# Altium schematic sheet Hamamatsu MEG MPPC - Series Hybrid - Rev1.SchDoc
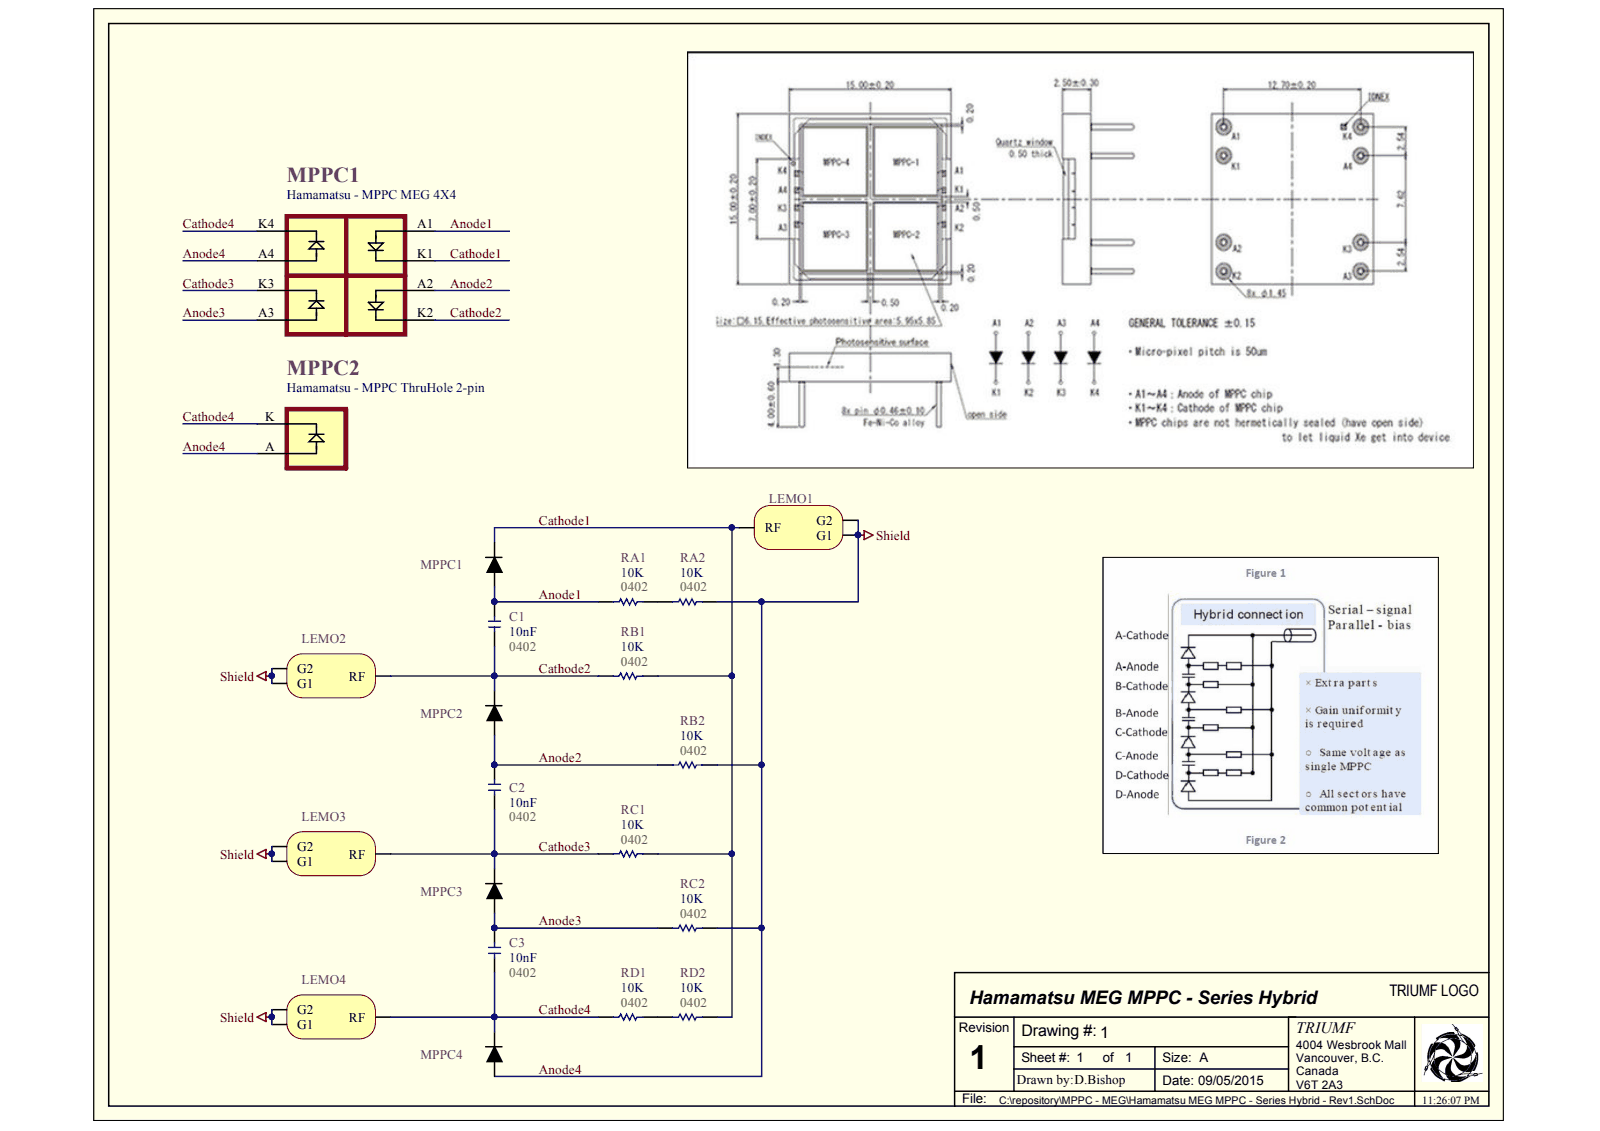
[diagram p0: Hamamatsu MEG MPPC - Series Hybrid - Rev1.SchDoc]

## Parts
C1  value 10nF  [0402]  (hidden comment: Chip capacitor 0402)
C2  value 10nF  [0402]  (hidden comment: Chip capacitor 0402)
C3  value 10nF  [0402]  (hidden comment: Chip capacitor 0402)
LEMO1  COAX Braid Termination  [BRAID-PIC]  (value not drawn)
LEMO2  COAX Braid Termination  [BRAID-PIC]  (value not drawn)
LEMO3  COAX Braid Termination  [BRAID-PIC]  (value not drawn)
LEMO4  COAX Braid Termination  [BRAID-PIC]  (value not drawn)
MPPC1  Hamamatsu - MPPC MEG 4X4  [Hamamatsu - MPPC MEG 4X4]
MPPC2  Hamamatsu - MPPC ThruHole 2-pin  [MPPC ThruHole]
RA1  value 10K  [0402]  (hidden comment: Chip Resistor 0402)
RA2  value 10K  [0402]  (hidden comment: Chip Resistor 0402)
RB1  value 10K  [0402]  (hidden comment: Chip Resistor 0402)
RB2  value 10K  [0402]  (hidden comment: Chip Resistor 0402)
RC1  value 10K  [0402]  (hidden comment: Chip Resistor 0402)
RC2  value 10K  [0402]  (hidden comment: Chip Resistor 0402)
RD1  value 10K  [0402]  (hidden comment: Chip Resistor 0402)
RD2  value 10K  [0402]  (hidden comment: Chip Resistor 0402)

## Nets
ANODE1: C1.2 MPPC1.A1 RA1.2
ANODE2: C2.2 MPPC1.A2 RB2.2
ANODE3: C3.2 MPPC1.A3 RC2.2
CATHODE1: LEMO1.RF MPPC1.K1 RB1.1 RC1.1 RD2.1
CATHODE2: C1.1 LEMO2.RF MPPC1.K2 RB1.2
CATHODE3: C2.1 LEMO3.RF MPPC1.K3 RC1.2
CATHODE4: C3.1 LEMO4.RF MPPC1.K4 MPPC2.K RD1.2
SHIELD: LEMO1.G1 LEMO1.G2 LEMO2.G1 LEMO2.G2 LEMO3.G1 LEMO3.G2 LEMO4.G1 LEMO4.G2 MPPC1.A4 MPPC2.A RA2.1 RB2.1 RC2.1
NetRA1_1: RA1.1 RA2.2
NetRD1_1: RD1.1 RD2.2

## Library data of the parts
C1-C3 = CAP-SMALL: Component Kind: Standard; Package: 0402; Library Name: MPPC Matrix Amplifier .SchLib
LEMO1-LEMO4 = BRAID-PIC: Braid connector - RG174, 179,179A; Manufacturer: TYCO; Part Number: 9-226176-2; Component Kind: Standard; Library Name: Hamamatsu MEG MPPC - Series Hybrid.SCHLIB
RA1 RA2 RB1 RB2 RC1 RC2 RD1 RD2 = Res1: Manufacturer: Yageo; Component Kind: Standard; Package: 0402; Signal Integrity: Res1; Simulation: RESISTOR
MPPC1 = Hamamatsu - MPPC MEG 4X4: Component Kind: Standard; Library Name: Hamamatsu MEG MPPC - Series Hybrid.SCHLIB
MPPC2 = Hamamatsu - MPPC ThruHole 2-pin: Component Kind: Standard; Library Name: Hamamatsu MEG MPPC - Series Hybrid.SCHLIB
